# Altium schematic sheet Pi_RFSoC_top.SchDoc(Pi_RFSoC_top)
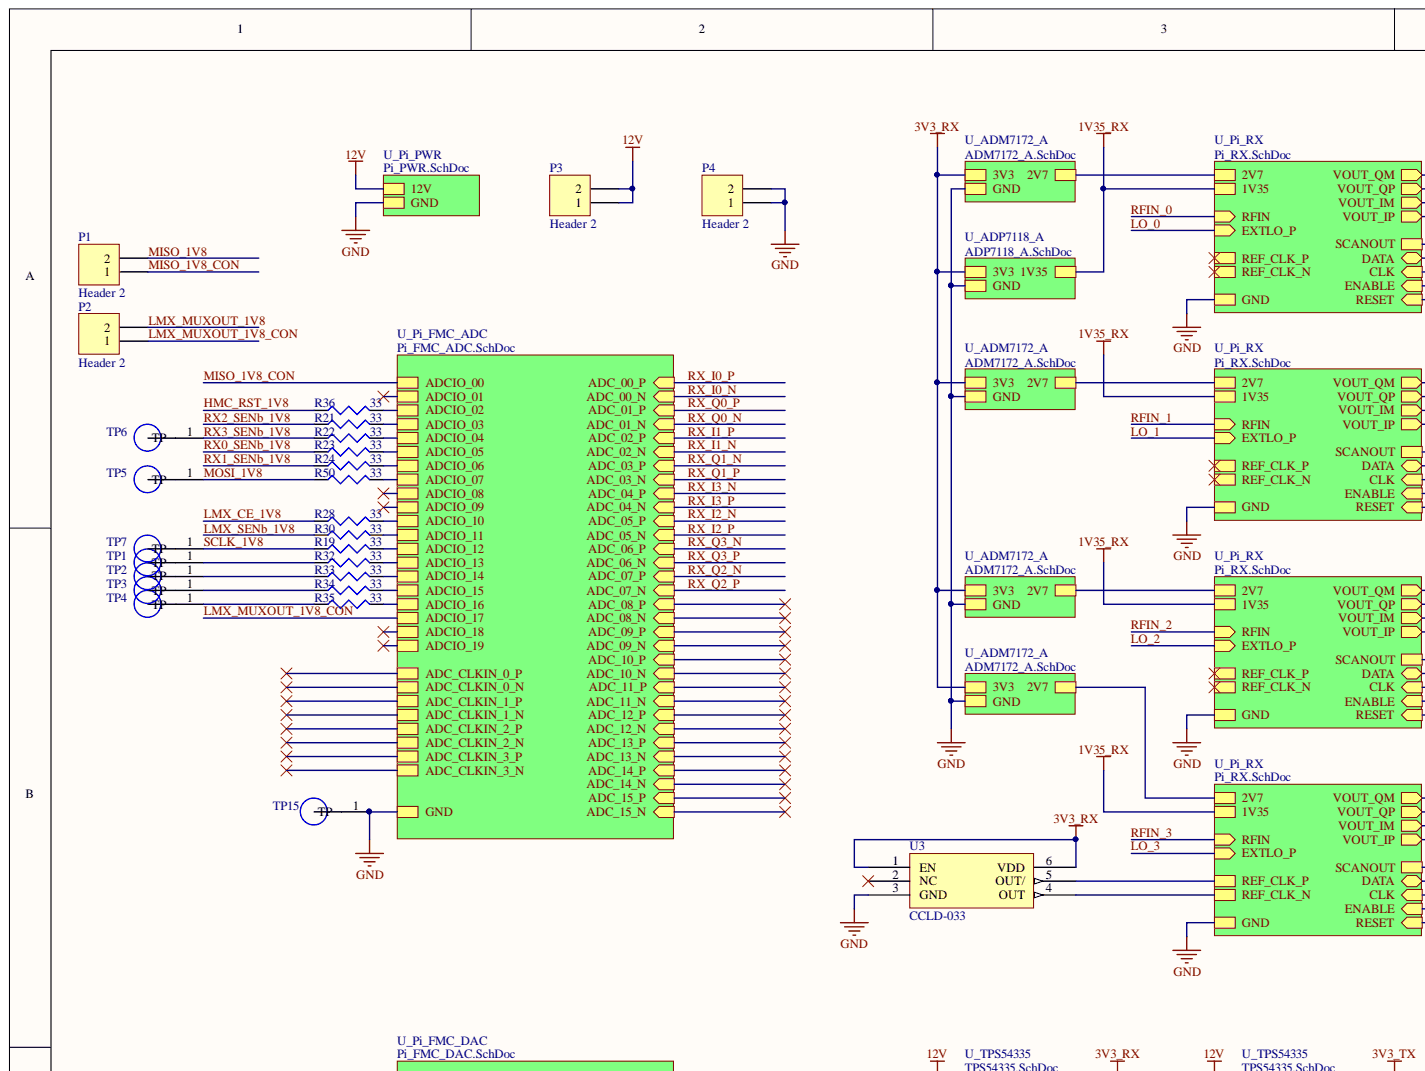
[diagram p0: Pi_RFSoC_top.SchDoc, top left]
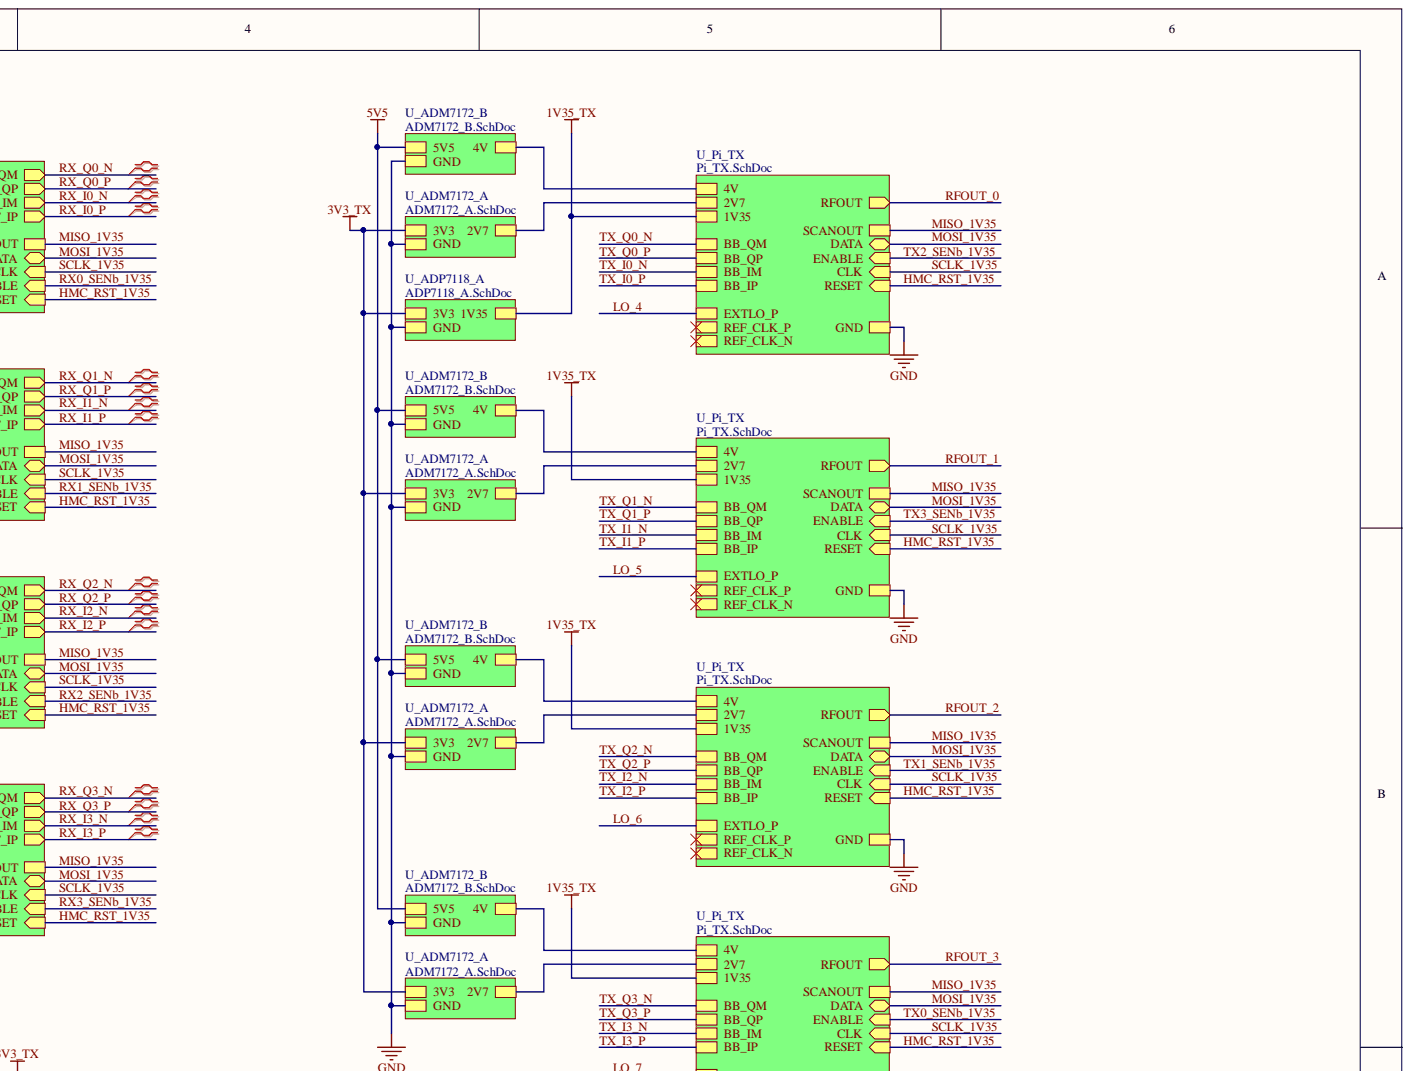
[diagram p1: Pi_RFSoC_top.SchDoc, top right]
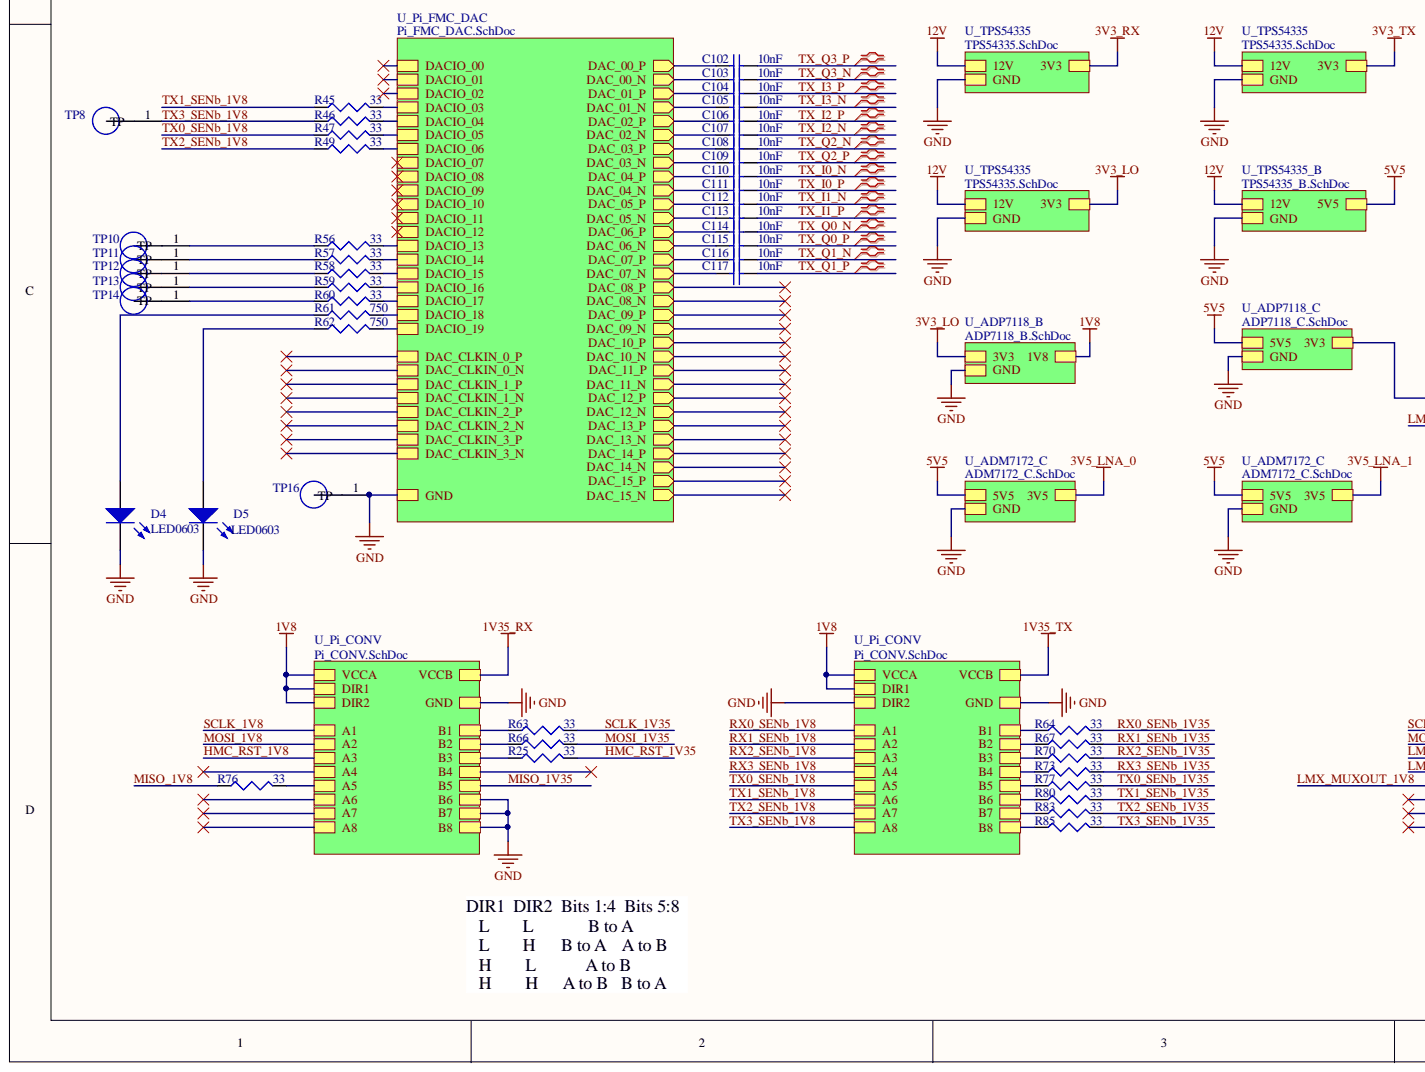
[diagram p2: Pi_RFSoC_top.SchDoc, bottom left]
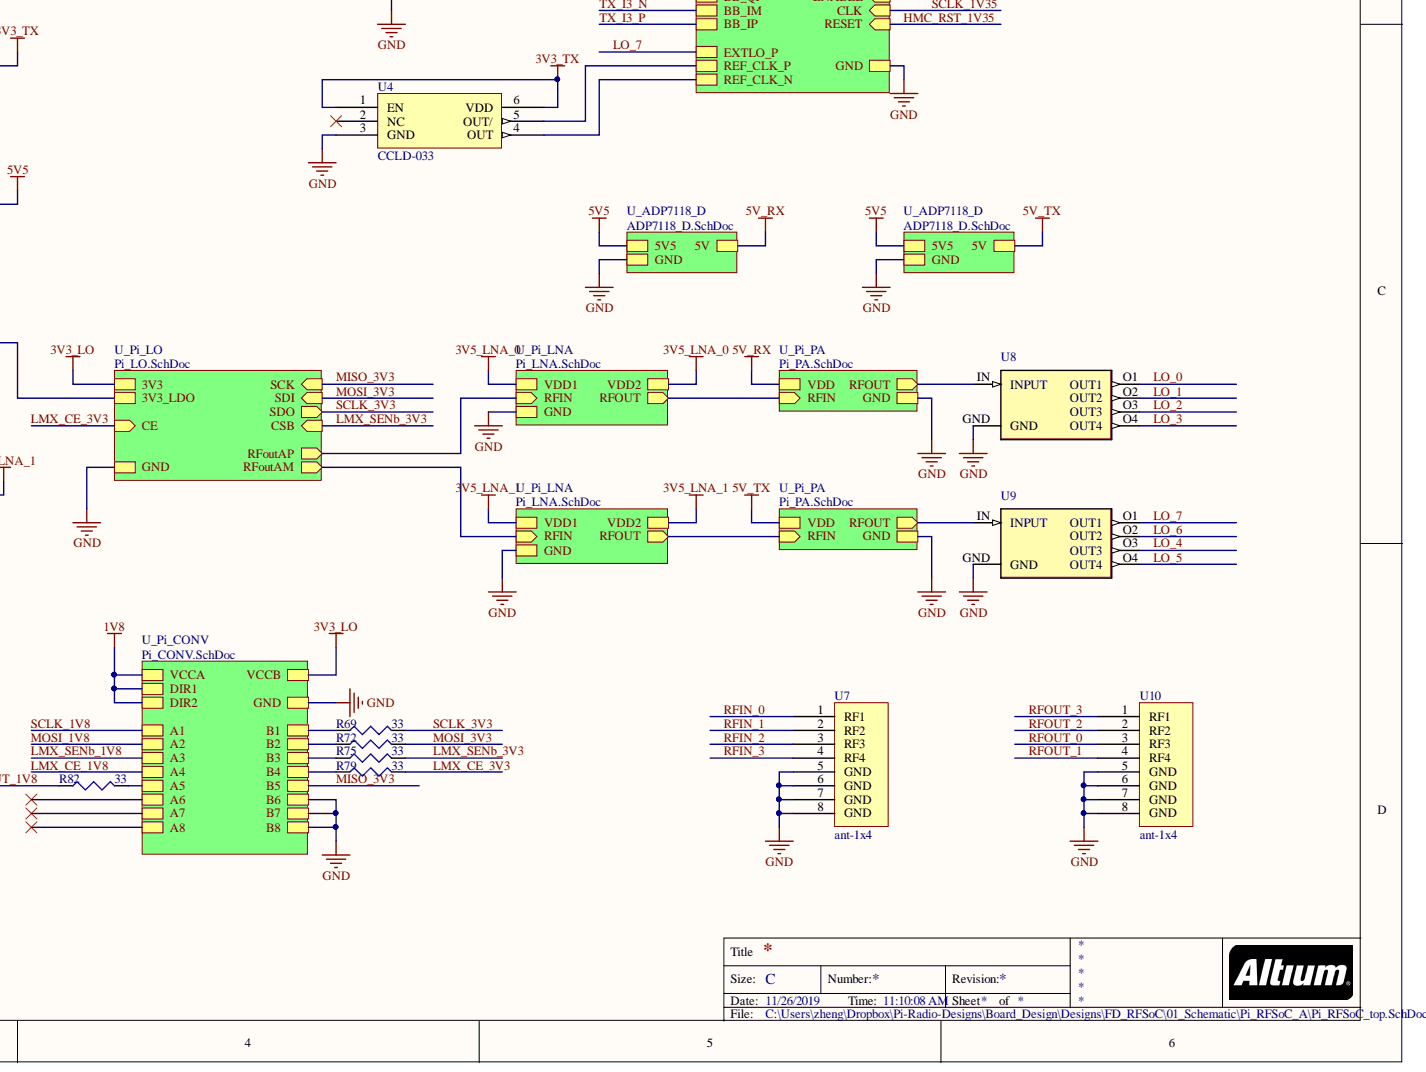
[diagram p3: Pi_RFSoC_top.SchDoc, bottom right]

## Parts
C102  10nF  [Johanson-0201]
C103  10nF  [Johanson-0201]
C104  10nF  [Johanson-0201]
C105  10nF  [Johanson-0201]
C106  10nF  [Johanson-0201]
C107  10nF  [Johanson-0201]
C108  10nF  [Johanson-0201]
C109  10nF  [Johanson-0201]
C110  10nF  [Johanson-0201]
C111  10nF  [Johanson-0201]
C112  10nF  [Johanson-0201]
C113  10nF  [Johanson-0201]
C114  10nF  [Johanson-0201]
C115  10nF  [Johanson-0201]
C116  10nF  [Johanson-0201]
C117  10nF  [Johanson-0201]
D4  LED0603  [LED-SMD-0603]
D5  LED0603  [LED-SMD-0603]
P1  Header 2  [HDR1X2]
P2  Header 2  [HDR1X2]
P3  Header 2  [HDR1X2]
P4  Header 2  [HDR1X2]
R19  33  [SMD-0603]
R21  33  [SMD-0603]
R22  33  [SMD-0603]
R23  33  [SMD-0603]
R24  33  [SMD-0603]
R25  33  [SMD-0603]
R28  33  [SMD-0603]
R30  33  [SMD-0603]
R32  33  [SMD-0603]
R33  33  [SMD-0603]
R34  33  [SMD-0603]
R35  33  [SMD-0603]
R36  33  [SMD-0603]
R45  33  [SMD-0603]
R46  33  [SMD-0603]
R47  33  [SMD-0603]
R49  33  [SMD-0603]
R50  33  [SMD-0603]
R56  33  [SMD-0603]
R57  33  [SMD-0603]
R58  33  [SMD-0603]
R59  33  [SMD-0603]
R60  33  [SMD-0603]
R61  750  [SMD-0603]
R62  750  [SMD-0603]
R63  33  [SMD-0603]
R64  33  [SMD-0603]
R66  33  [SMD-0603]
R67  33  [SMD-0603]
R69  33  [SMD-0603]
R70  33  [SMD-0603]
R72  33  [SMD-0603]
R73  33  [SMD-0603]
R75  33  [SMD-0603]
R76  33  [SMD-0603]
R77  33  [SMD-0603]
R79  33  [SMD-0603]
R80  33  [SMD-0603]
R82  33  [SMD-0603]
R83  33  [SMD-0603]
R85  33  [SMD-0603]
TP1  Keystone_5019_Testpoint  [Keystone-5019-Testpoint]  (value not drawn)
TP2  Keystone_5019_Testpoint  [Keystone-5019-Testpoint]  (value not drawn)
TP3  Keystone_5019_Testpoint  [Keystone-5019-Testpoint]  (value not drawn)
TP4  Keystone_5019_Testpoint  [Keystone-5019-Testpoint]  (value not drawn)
TP5  Keystone_5019_Testpoint  [Keystone-5019-Testpoint]  (value not drawn)
TP6  Keystone_5019_Testpoint  [Keystone-5019-Testpoint]  (value not drawn)
TP7  Keystone_5019_Testpoint  [Keystone-5019-Testpoint]  (value not drawn)
TP8  Keystone_5019_Testpoint  [Keystone-5019-Testpoint]  (value not drawn)
TP10  Keystone_5019_Testpoint  [Keystone-5019-Testpoint]  (value not drawn)
TP11  Keystone_5019_Testpoint  [Keystone-5019-Testpoint]  (value not drawn)
TP12  Keystone_5019_Testpoint  [Keystone-5019-Testpoint]  (value not drawn)
TP13  Keystone_5019_Testpoint  [Keystone-5019-Testpoint]  (value not drawn)
TP14  Keystone_5019_Testpoint  [Keystone-5019-Testpoint]  (value not drawn)
TP15  Keystone_5019_Testpoint  [Keystone-5019-Testpoint]  (value not drawn)
TP16  Keystone_5019_Testpoint  [Keystone-5019-Testpoint]  (value not drawn)
U3  CCLD-033  [CCLD-033]
U4  CCLD-033  [CCLD-033]
U7  ant-1x4  [ANT-1x4-10mil]
U8  PDW06089  [PDW06089]  (value not drawn)
U9  PDW06089  [PDW06089]  (value not drawn)
U10  ant-1x4  [ANT-1x4-10mil]

## Nets
3V3_RX: U3.1(EN) U3.6(VDD)
3V3_TX: U4.1(EN) U4.6(VDD)
12V: P3.1 P3.2
GND: D4.2 D5.2 P4.1 P4.2 TP15.1 TP16.1 U3.3(GND) U4.3(GND) U7.5(GND) U7.6(GND) U7.7(GND) U7.8(GND) U8.GND U9.GND U10.5(GND) U10.6(GND) U10.7(GND) U10.8(GND)
HMC_RST_1V8: R36.1
HMC_RST_1V35: R25.2
LMX_CE_1V8: R28.1
LMX_CE_3V3: R79.2
LMX_MUXOUT_1V8: P2.2 R82.1
LMX_MUXOUT_1V8_CON: P2.1
LMX_SENb_1V8: R30.1
LMX_SENb_3V3: R75.2
LO_0: U8.O1(OUT1)
LO_1: U8.O2(OUT2)
LO_2: U8.O3(OUT3)
LO_3: U8.O4(OUT4)
LO_4: U9.O3(OUT3)
LO_5: U9.O4(OUT4)
LO_6: U9.O2(OUT2)
LO_7: U9.O1(OUT1)
MISO_1V8: P1.2 R76.1
MISO_1V8_CON: P1.1
MOSI_1V8: R50.1 TP5.1
MOSI_1V35: R66.2
MOSI_3V3: R72.2
RFIN_0: U7.1(RF1)
RFIN_1: U7.2(RF2)
RFIN_2: U7.3(RF3)
RFIN_3: U7.4(RF4)
RFOUT_0: U10.3(RF3)
RFOUT_1: U10.4(RF4)
RFOUT_2: U10.2(RF2)
RFOUT_3: U10.1(RF1)
RX0_SENb_1V8: R23.1
RX0_SENb_1V35: R64.2
RX1_SENb_1V8: R24.1
RX1_SENb_1V35: R67.2
RX2_SENb_1V8: R21.1
RX2_SENb_1V35: R70.2
RX3_SENb_1V8: R22.1 TP6.1
RX3_SENb_1V35: R73.2
SCLK_1V8: R19.1 TP7.1
SCLK_1V35: R63.2
SCLK_3V3: R69.2
TX0_SENb_1V8: R47.1
TX0_SENb_1V35: R77.2
TX1_SENb_1V8: R45.1
TX1_SENb_1V35: R80.2
TX2_SENb_1V8: R49.1
TX2_SENb_1V35: R83.2
TX3_SENb_1V8: R46.1 TP8.1
TX3_SENb_1V35: R85.2
TX_I0_N: C110.2
TX_I0_P: C111.2
TX_I1_N: C112.2
TX_I1_P: C113.2
TX_I2_N: C107.2
TX_I2_P: C106.2
TX_I3_N: C105.2
TX_I3_P: C104.2
TX_Q0_N: C114.2
TX_Q0_P: C115.2
TX_Q1_N: C116.2
TX_Q1_P: C117.2
TX_Q2_N: C108.2
TX_Q2_P: C109.2
TX_Q3_N: C103.2
TX_Q3_P: C102.2
NetC102_1: C102.1
NetC103_1: C103.1
NetC104_1: C104.1
NetC105_1: C105.1
NetC106_1: C106.1
NetC107_1: C107.1
NetC108_1: C108.1
NetC109_1: C109.1
NetC110_1: C110.1
NetC111_1: C111.1
NetC112_1: C112.1
NetC113_1: C113.1
NetC114_1: C114.1
NetC115_1: C115.1
NetC116_1: C116.1
NetC117_1: C117.1
NetD4_1: D4.1 R61.1
NetD5_1: D5.1 R62.1
NetR19_2: R19.2
NetR21_2: R21.2
NetR22_2: R22.2
NetR23_2: R23.2
NetR24_2: R24.2
NetR25_1: R25.1
NetR28_2: R28.2
NetR30_2: R30.2
NetR32_1: R32.1 TP1.1
NetR32_2: R32.2
NetR33_1: R33.1 TP2.1
NetR33_2: R33.2
NetR34_1: R34.1 TP3.1
NetR34_2: R34.2
NetR35_1: R35.1 TP4.1
NetR35_2: R35.2
NetR36_2: R36.2
NetR45_2: R45.2
NetR46_2: R46.2
NetR47_2: R47.2
NetR49_2: R49.2
NetR50_2: R50.2
NetR56_1: R56.1 TP10.1
NetR56_2: R56.2
NetR57_1: R57.1 TP11.1
NetR57_2: R57.2
NetR58_1: R58.1 TP12.1
NetR58_2: R58.2
NetR59_1: R59.1 TP13.1
NetR59_2: R59.2
NetR60_1: R60.1 TP14.1
NetR60_2: R60.2
NetR61_2: R61.2
NetR62_2: R62.2
NetR63_1: R63.1
NetR64_1: R64.1
NetR66_1: R66.1
NetR67_1: R67.1
NetR69_1: R69.1
NetR70_1: R70.1
NetR72_1: R72.1
NetR73_1: R73.1
NetR75_1: R75.1
NetR76_2: R76.2
NetR77_1: R77.1
NetR79_1: R79.1
NetR80_1: R80.1
NetR82_2: R82.2
NetR83_1: R83.1
NetR85_1: R85.1
NetU3_2: U3.2(NC)
NetU3_4: U3.4(OUT)
NetU3_5: U3.5(OUT/)
NetU4_2: U4.2(NC)
NetU4_4: U4.4(OUT)
NetU4_5: U4.5(OUT/)
NetU8_IN: U8.IN(INPUT)
NetU9_IN: U9.IN(INPUT)
1V8:
1V35_RX:
1V35_TX:
2V7:
3V3:
3V3_LO:
3V5_LNA_0:
3V5_LNA_1:
4V:
5V5:
5V_RX:
5V_TX:
A4:
A6:
A7:
A8:
ADC_08_N:
ADC_08_P:
ADC_09_N:
ADC_09_P:
ADC_10_N:
ADC_10_P:
ADC_11_N:
ADC_11_P:
ADC_12_N:
ADC_12_P:
ADC_13_N:
ADC_13_P:
ADC_14_N:
ADC_14_P:
ADC_15_N:
ADC_15_P:
ADC_CLKIN_0_N:
ADC_CLKIN_0_P:
ADC_CLKIN_1_N:
ADC_CLKIN_1_P:
ADC_CLKIN_2_N:
ADC_CLKIN_2_P:
ADC_CLKIN_3_N:
ADC_CLKIN_3_P:
ADCIO_01:
ADCIO_08:
ADCIO_09:
ADCIO_18:
ADCIO_19:
B4:
DAC_08_N:
DAC_08_P:
DAC_09_N:
DAC_09_P:
DAC_10_N:
DAC_10_P:
DAC_11_N:
DAC_11_P:
DAC_12_N:
DAC_12_P:
DAC_13_N:
DAC_13_P:
DAC_14_N:
DAC_14_P:
DAC_15_N:
DAC_15_P:
DAC_CLKIN_0_N:
DAC_CLKIN_0_P:
DAC_CLKIN_1_N:
DAC_CLKIN_1_P:
DAC_CLKIN_2_N:
DAC_CLKIN_2_P:
DAC_CLKIN_3_N:
DAC_CLKIN_3_P:
DACIO_00:
DACIO_01:
DACIO_02:
DACIO_07:
DACIO_08:
DACIO_09:
DACIO_10:
DACIO_11:
DACIO_12:
MISO_1V35:
MISO_3V3:
REF_CLK_N:
REF_CLK_P:
RFIN:
RFOUT:
RX_I0_N:
RX_I0_P:
RX_I1_N:
RX_I1_P:
RX_I2_N:
RX_I2_P:
RX_I3_N:
RX_I3_P:
RX_Q0_N:
RX_Q0_P:
RX_Q1_N:
RX_Q1_P:
RX_Q2_N:
RX_Q2_P:
RX_Q3_N:
RX_Q3_P:

## Library data of the parts
C102-C117 = CAP0201: Capacitor 0201 Imperial; Library Name: LCR.SchLib; Component Kind: Standard
D4 D5 = LED0603: LED 0603; Library Name: LCR.SchLib; Component Kind: Standard
P1-P4 = Header 2: Header, 2-Pin; Signal Integrity: Connector; Library Name: Miscellaneous Connectors.IntLib; LatestRevisionNote: Re-released for DXP Platform.; LatestRevisionDate: 17-Jul-2002; Component Kind: Standard; Publisher: Altium Limited
R19 R21-R25 R28 R30 R32-R36 R45-R47 R49 R50 R56-R64 R66 R67 R69 R70 R72 R73 R75-R77 R79 R80 R82 R83 R85 = RES0603: Resistor-0603; Library Name: LCR.SchLib; Component Kind: Standard
TP1-TP8 TP10-TP16 = 5019: MF: Keystone Electronics; SnapEDA_Link: https://www.snapeda.com/parts/5019/Keystone%20Electronics/view-part/?ref=snap; Price: None; Package: 2010 Keystone; MP: 5019; Library Name: Connectors.SchLib; Description: Keystone_5019_Testpoint; Component Kind: Standard; Availability: Unavailable
U3 U4 = CCLD-033: CCLD-033 5×7mm SMD  LVDS Clock Oscillator; Component Kind: Standard; Library Name: FullyDigital.SchLib
U7 U10 = ant-1x4: Patch Antenna 1x4; Library Name: antenna.SchLib; Component Kind: Standard
U8 U9 = PDW06089: 6-18ghz 4-Way Wilkinson Pwr Di; MF: Knowles Dielectric; SnapEDA_Link: https://www.snapeda.com/parts/PDW06089/Knowles%20Dielectric%20Labs/view-part/?ref=snap; Price: None; Package: Module Knowles; MP: PDW06089; Component Kind: Standard; Availability: Unavailable; Library Name: FullyDigital.SchLib
